# Altium schematic sheet FMC.SchDoc(FMC)
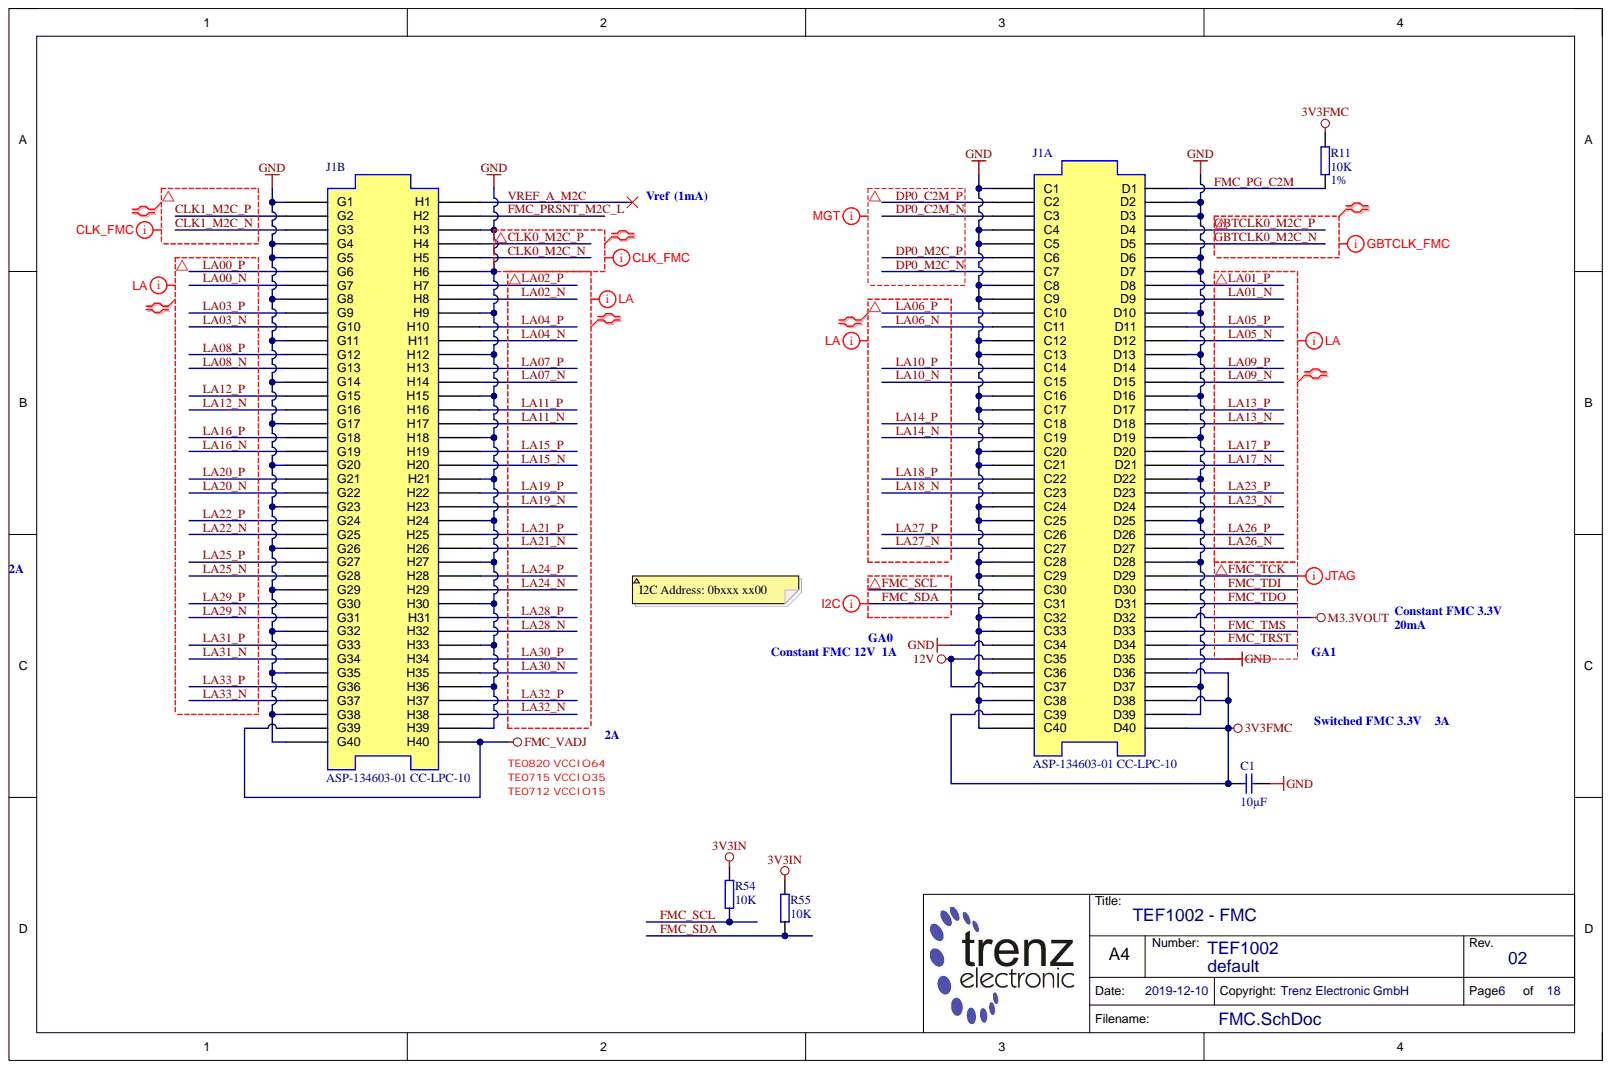
[diagram p0: FMC.SchDoc]

## Parts
C1  10µF  [CAPC2012X135N]
J1  ASP-134603-01 CC-LPC-10  [ASP-134603-01]  (parts A,B)
R11  10K  Tolerance: 1%  [RESC1005X40N]
R54  10K  [RESC1005X40N]
R55  10K  [RESC1005X40N]

## Nets
3V3FMC: C1.2 J1.C39 J1.D36 J1.D38 J1.D40 R11.1
3V3IN: R54.1 R55.1
12V: J1.C35 J1.C37
FMC_VADJ: J1.G39 J1.H40
GND: C1.1 J1.C1 J1.C4 J1.C5 J1.C8 J1.C9 J1.C12 J1.C13 J1.C16 J1.C17 J1.C20 J1.C21 J1.C24 J1.C25 J1.C28 J1.C29 J1.C32 J1.C33 J1.C34 J1.C36 J1.C38 J1.C40 J1.D2 J1.D3 J1.D6 J1.D7 J1.D10 J1.D13 J1.D16 J1.D19 J1.D22 J1.D25 J1.D28 J1.D35 J1.D37 J1.D39 J1.G1 J1.G4 J1.G5 J1.G8 J1.G11 J1.G14 J1.G17 J1.G20 J1.G23 J1.G26 J1.G29 J1.G32 J1.G35 J1.G38 J1.G40 J1.H3 J1.H6 J1.H9 J1.H12 J1.H15 J1.H18 J1.H21 J1.H24 J1.H27 J1.H30 J1.H33 J1.H36 J1.H39
M3.3VOUT: J1.D32
CLK0_M2C_N: J1.H5
CLK0_M2C_P: J1.H4
CLK1_M2C_N: J1.G3
CLK1_M2C_P: J1.G2
DP0_C2M_N: J1.C3
DP0_C2M_P: J1.C2
DP0_M2C_N: J1.C7
DP0_M2C_P: J1.C6
FMC_PG_C2M: J1.D1 R11.2
FMC_PRSNT_M2C_L: J1.H2
FMC_SCL: J1.C30 R54.2
FMC_SDA: J1.C31 R55.2
FMC_TCK: J1.D29
FMC_TDI: J1.D30
FMC_TDO: J1.D31
FMC_TMS: J1.D33
FMC_TRST: J1.D34
GBTCLK0_M2C_N: J1.D5
GBTCLK0_M2C_P: J1.D4
LA00_N: J1.G7
LA00_P: J1.G6
LA01_N: J1.D9
LA01_P: J1.D8
LA02_N: J1.H8
LA02_P: J1.H7
LA03_N: J1.G10
LA03_P: J1.G9
LA04_N: J1.H11
LA04_P: J1.H10
LA05_N: J1.D12
LA05_P: J1.D11
LA06_N: J1.C11
LA06_P: J1.C10
LA07_N: J1.H14
LA07_P: J1.H13
LA08_N: J1.G13
LA08_P: J1.G12
LA09_N: J1.D15
LA09_P: J1.D14
LA10_N: J1.C15
LA10_P: J1.C14
LA11_N: J1.H17
LA11_P: J1.H16
LA12_N: J1.G16
LA12_P: J1.G15
LA13_N: J1.D18
LA13_P: J1.D17
LA14_N: J1.C19
LA14_P: J1.C18
LA15_N: J1.H20
LA15_P: J1.H19
LA16_N: J1.G19
LA16_P: J1.G18
LA17_N: J1.D21
LA17_P: J1.D20
LA18_N: J1.C23
LA18_P: J1.C22
LA19_N: J1.H23
LA19_P: J1.H22
LA20_N: J1.G22
LA20_P: J1.G21
LA21_N: J1.H26
LA21_P: J1.H25
LA22_N: J1.G25
LA22_P: J1.G24
LA23_N: J1.D24
LA23_P: J1.D23
LA24_N: J1.H29
LA24_P: J1.H28
LA25_N: J1.G28
LA25_P: J1.G27
LA26_N: J1.D27
LA26_P: J1.D26
LA27_N: J1.C27
LA27_P: J1.C26
LA28_N: J1.H32
LA28_P: J1.H31
LA29_N: J1.G31
LA29_P: J1.G30
LA30_N: J1.H35
LA30_P: J1.H34
LA31_N: J1.G34
LA31_P: J1.G33
LA32_N: J1.H38
LA32_P: J1.H37
LA33_N: J1.G37
LA33_P: J1.G36
VREF_A_M2C: J1.H1

## Library data of the parts
C1 = 24380: KON 10µF 16V X7R 10% SMD BF 2012 (0805); Database Table Name: C_2012_0805; Mixed: SMT; Preferred: Yes; Temperature-Range: -55/+125; Value: 10u; AN: 24380; Case: 2012 (0805); Component Kind: Standard; Library Name: TE.DbLib; Technology: X7R; Tolerance: 10%; Voltage: 16V
J1 = 24996: ASP-134603-01 female CC-LPC-10; Database Table Name: CON_B2B; Mixed: SMT; Trenz-Stock: Yes; AN: 24996; Component Kind: Standard; H-ART: ASP-134603-01; Library Name: TE.DbLib; HelpURL: B:\AD\adlib\datasheet\ASP-134603-01
R11 R54 R55 = 22750: WID SMD 10K 1% 0,063W BF 1005 (0402); Database Table Name: R_1005_0402; H-ART: RC0402FR-0710KL; Mixed: SMT; Preferred: Yes; AN: 22750; Case: 1005 (0402); Component Kind: Standard; Library Name: TE.DbLib; Technology: TK100; Value: 10K
  R54 R55: Tolerance: 1%
